# Altium schematic sheet GPS.SchDoc(U_GPS)
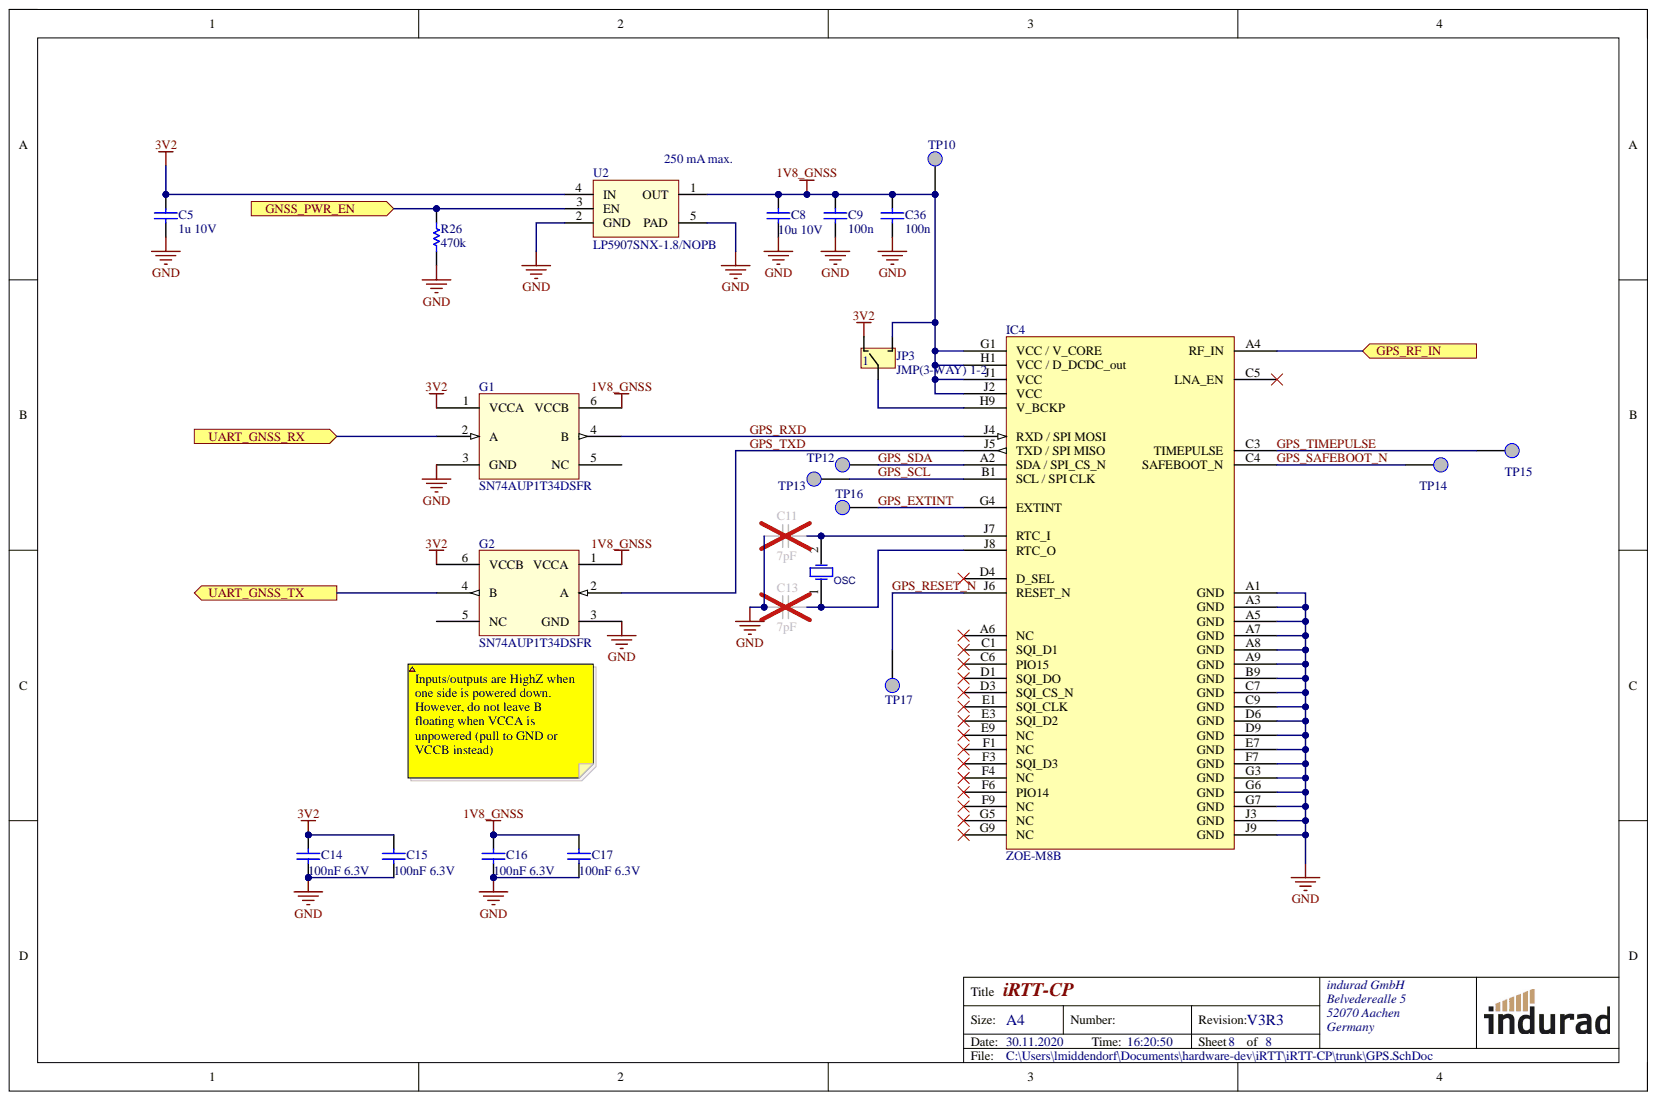
[diagram p0: GPS.SchDoc]

## Parts
C5  1u 10V  [0805_N_(2012)]
C8  10u 10V  value 10u  [0805_N_(2012)]
C9  100n  [0402_N_(1005)]
C11  7pF  [0402_N_(1005)]
C13  7pF  [0402_N_(1005)]
C14  100nF 6.3V  Voltage - Rated: 6.3V  [0201_N_(0603)]
C15  100nF 6.3V  Voltage - Rated: 6.3V  [0201_N_(0603)]
C16  100nF 6.3V  Voltage - Rated: 6.3V  [0201_N_(0603)]
C17  100nF 6.3V  Voltage - Rated: 6.3V  [0201_N_(0603)]
C36  100n  [0402_N_(1005)]
G1  SN74AUP1T34DSFR  [SON6]
G2  SN74AUP1T34DSFR  [SON6]
IC4  ZOE-M8B  [ZOE-M8]
JP3  JMP(3-WAY) 1-2  [JM0402(SET_1_2)(3WAY)]
OSC  CM8V-T1A-32.768kHz-7pF-20PPM  [Quarz_CM8V]  (value not drawn)
R26  470k  [0201_N_(0603)]
TP10  TP  [TP1.0d0.7]  (no BOM)
TP12  TP  [TP0.6d0.3]  (no BOM)
TP13  TP  [TP0.6d0.3]  (no BOM)
TP14  TP  [TP0.6d0.3]  (no BOM)
TP15  TP  [TP0.6d0.3]  (no BOM)
TP16  TP  [TP0.6d0.3]  (no BOM)
TP17  TP  [TP0.6d0.3]  (no BOM)
U2  LP5907SNX-1.8/NOPB  [4-XDFN]

## Ports
GNSS_PWR_EN, GPS_RF_IN, UART_GNSS_RX, UART_GNSS_TX

## Nets
1V8_GNSS: C8.2 C9.2 C16.1 C17.1 C36.2 G1.6(VCCB) G2.1(VCCA) IC4.G1(VCC_/_V_CORE) IC4.H1(VCC_/_D_DCDC_out) IC4.J1(VCC) IC4.J2(VCC) JP3.3 TP10.1 U2.1(OUT)
3V2: C5.2 C14.1 C15.1 G1.1(VCCA) G2.6(VCCB) JP3.1 U2.4(IN)
GND: C5.1 C8.1 C9.1 C11.1 C13.1 C14.2 C15.2 C16.2 C17.2 C36.1 G1.3(GND) G2.3(GND) IC4.A1(GND) IC4.A3(GND) IC4.A5(GND) IC4.A7(GND) IC4.A8(GND) IC4.A9(GND) IC4.B9(GND) IC4.C7(GND) IC4.C9(GND) IC4.D6(GND) IC4.D9(GND) IC4.E7(GND) IC4.F7(GND) IC4.G3(GND) IC4.G6(GND) IC4.G7(GND) IC4.J3(GND) IC4.J9(GND) R26.2 U2.2(GND) U2.5(PAD)
GNSS_PWR_EN: R26.1 U2.3(EN) ; port GNSS_PWR_EN
GPS_EXTINT: IC4.G4(EXTINT) TP16.1
GPS_RESET_N: IC4.J6(RESET_N) TP17.1
GPS_RF_IN: IC4.A4(RF_IN) ; port GPS_RF_IN
GPS_RXD: G1.4(B) IC4.J4(RXD_/_SPI_MOSI)
GPS_SAFEBOOT_N: IC4.C4(SAFEBOOT_N) TP14.1
GPS_SCL: IC4.B1(SCL_/_SPI_CLK) TP13.1
GPS_SDA: IC4.A2(SDA_/_SPI_CS_N) TP12.1
GPS_TIMEPULSE: IC4.C3(TIMEPULSE) TP15.1
GPS_TXD: G2.2(A) IC4.J5(TXD_/_SPI_MISO)
UART_GNSS_RX: G1.2(A) ; port UART_GNSS_RX
UART_GNSS_TX: G2.4(B) ; port UART_GNSS_TX
NetC11_2: C11.2 IC4.J7(RTC_I) OSC.2
NetC13_2: C13.2 IC4.J8(RTC_O) OSC.1
NetG1_5: G1.5(NC)
NetG2_5: G2.5(NC)
NetIC4_A6: IC4.A6(NC)
NetIC4_C1: IC4.C1(SQI_D1)
NetIC4_C5: IC4.C5(LNA_EN)
NetIC4_C6: IC4.C6(PIO15)
NetIC4_D1: IC4.D1(SQI_DO)
NetIC4_D3: IC4.D3(SQI_CS_N)
NetIC4_D4: IC4.D4(D_SEL)
NetIC4_E1: IC4.E1(SQI_CLK)
NetIC4_E3: IC4.E3(SQI_D2)
NetIC4_E9: IC4.E9(NC)
NetIC4_F1: IC4.F1(NC)
NetIC4_F3: IC4.F3(SQI_D3)
NetIC4_F4: IC4.F4(NC)
NetIC4_F6: IC4.F6(PIO14)
NetIC4_F9: IC4.F9(NC)
NetIC4_G5: IC4.G5(NC)
NetIC4_G9: IC4.G9(NC)
NetIC4_H9: IC4.H9(V_BCKP) JP3.2

## Library data of the parts
C5 = Cap: Capacitor; Manufacturer: KEMET; Manufacturer Part Number: C0805C105K8RACTU; Component Kind: Standard; ComponentLink1Description: http://www.farnell.com/datasheets/2207129.pdf; ComponentLink1URL: http://www.farnell.com/datasheets/2207129.pdf; ComponentLink2Description: http://www.farnell.com/datasheets/2205776.pdf; ComponentLink2URL: http://www.farnell.com/datasheets/2205776.pdf; ComponentLink3Description: http://www.farnell.com/cad/2268620.zip; ComponentLink3URL: http://www.farnell.com/cad/2268620.zip; Pricing 1: 10=0.0757, 100=0.0474, 500=0.028, 1000=0.0259, 2000=0.0238 (GBP); RoHS: YES; Signal Integrity: Cap; Simulation: CAP; Stock 1: 2580; Supplier 1: Farnell; Supplier Part Number 1: 2409048; Value: 1u 10V; Library Name: Indurad.SchLib
C8 = Cap: Capacitor; Component Kind: Standard; Signal Integrity: Cap; Simulation: CAP; Supplier 1: Farnell; Supplier Part Number 1: 2118132; Library Name: Indurad.SchLib
C9 C36 = Cap: Capacitor; Manufacturer: Murata Electronics North America; Manufacturer Part Number: GRM155R70J104KA01D; Capacitance: 0.1µF; Temperature Coefficient: X7R; Tolerance: ±10%; Voltage - Rated: 6.3V; Applications: General Purpose; Category: Capacitors; Component Kind: Standard; ComponentLink1Description: http://www.murata.com/~/media/webrenewal/support/library/catalog/products/capacitor/mlcc/c02e.ashx; ComponentLink1URL: http://www.murata.com/~/media/webrenewal/support/library/catalog/products/capacitor/mlcc/c02e.ashx; Features: -; Height - Seated (Max): -; Lead Spacing: -; Mounting Type: Surface Mount, MLCC; Operating Temperature: -55°C ~ 125°C; Package / Case: 0402 (1005 Metric); Packaging: Cut Tape (CT); Pricing 1: 1=0.1, 10=0.025, 50=0.0136, 100=0.0114, 250=0.00936, 500=0.008, 1000=0.00622, 5000=0.00519 (EUR); Ratings: -; RoHS: RoHS Compliant; Signal Integrity: Cap; Simulation: CAP; Size / Dimension: 0.039" L x 0.020" W (1.00mm x 0.50mm); Stock 1: 430852; Supplier 1: Digi-Key; Supplier Part Number 1: 490-6319-1-ND; Thickness (Max): 0.022" (0.55mm); Value: 100n; Library Name: Indurad.SchLib
C11 C13 = Cap: Capacitor; Manufacturer: Murata Electronics North America; Manufacturer Part Number: GRM1555C1H7R0DA01D; Notification: Current market demand for these product types have resulted in fluctuating and extending lead times. Lead times may differ.; Temperature Coefficient: C0G, NP0; Tolerance: ±0.5pF; Voltage - Rated: 50V; Applications: General Purpose; Category: Capacitors; Component Kind: Standard; ComponentLink1Description: https://www.murata.com/~/media/webrenewal/support/library/catalog/products/capacitor/mlcc/c02e.ashx?la=en-us; ComponentLink1URL: https://www.murata.com/~/media/webrenewal/support/library/catalog/products/capacitor/mlcc/c02e.ashx?la=en-us; Features: -; Height - Seated (Max): -; Lead Spacing: -; Lead Style: -; Mounting Type: Surface Mount, MLCC; Operating Temperature: -55°C ~ 125°C; Package / Case: 0402 (1005 Metric); Packaging: Cut Tape (CT); Part Status: Active; Pricing 1: 1=0.08, 10=0.027, 100=0.012, 500=0.00854, 1000=0.00671, 2500=0.0061, 5000=0.00561 (EUR); Ratings: -; RoHS: RoHS Compliant; Signal Integrity: Cap; Simulation: CAP; Size / Dimension: 0.039" L x 0.020" W (1.00mm x 0.50mm); Stock 1: 119890; Supplier 1: Digi-Key; Supplier Part Number 1: 490-5878-1-ND; Thickness (Max): 0.022" (0.55mm); Value: 7pF; Library Name: Indurad.SchLib
C14-C17 = Cap: Capacitor; Manufacturer: TDK Corporation; Manufacturer Part Number: C0603X5R0J104K030BC; Capacitance: 0.10µF; Temperature Coefficient: X5R; Tolerance: ±10%; Applications: General Purpose; Category: Capacitors; Component Kind: Standard; ComponentLink1Description: https://product.tdk.com/info/en/catalog/datasheets/mlcc_commercial_general_en.pdf; ComponentLink1URL: https://product.tdk.com/info/en/catalog/datasheets/mlcc_commercial_general_en.pdf; Features: -; Height - Seated (Max): -; Lead Spacing: -; Lead Style: -; Mounting Type: Surface Mount, MLCC; Operating Temperature: -55°C ~ 85°C; Package / Case: 0201 (0603 Metric); Packaging: Cut Tape (CT); Pricing 1: 1=0.09, 10=0.028, 100=0.0131, 250=0.01072, 500=0.00916, 1000=0.00713, 5000=0.00594 (EUR); Ratings: -; RoHS: RoHS Compliant; Signal Integrity: Cap; Simulation: CAP; Size / Dimension: 0.024" L x 0.012" W (0.60mm x 0.30mm); Stock 1: 1826831; Supplier 1: Digi-Key; Supplier Part Number 1: 445-1796-1-ND; Thickness (Max): 0.013" (0.33mm); Value: 100nF 6.3V; Library Name: Indurad.SchLib
G1 G2 = SN74AUP1T34DSFR: 1-Bit Unidirectional Voltage-Level Translator; Manufacturer: Texas Instruments; Channels per Circuit: 1; Output Type: Non-Inverted; Translator Type: Voltage Level; Base Part Number: 74AUP1T34; Category: Integrated Circuits (ICs); Channel Type: Unidirectional; Component Kind: Standard; ComponentLink1Description: http://www.ti.com/lit/ds/symlink/sn74aup1t34.pdf; ComponentLink1URL: http://www.ti.com/lit/ds/symlink/sn74aup1t34.pdf; Data Rate: -; Features: -; Input Signal: -; Manufacturer Part Number: SN74AUP1T34DSFR; Mounting Type: Surface Mount; Operating Temperature: -40°C ~ 85°C (TA); Output Signal: -; Package / Case: 6-XFDFN; Packaging: Cut Tape (CT); Part Status: Active; Pricing 1: 1=0.55, 10=0.486, 100=0.373, 500=0.29484, 1000=0.23587 (EUR); RoHS: RoHS Compliant; Stock 1: 10074; Supplier 1: Digi-Key; Supplier Device Package: 6-SON (1x1); Supplier Part Number 1: 296-36719-1-ND
  G2: Library Name: IC_Integrated_Circuit.SchLib; Supplier 2: Arrow; Supplier 3: Mouser; Supplier Part Number 2: SN74AUP1T34DSFR; Supplier Part Number 3: 595-SN74AUP1T34DSFR
  G1: Library Name: Indurad.SchLib
IC4 = ZOE-M8B: Manufacturer: u-blox; Component Kind: Standard; ComponentLink1Description: Datasheet; ComponentLink1URL: https://www.u-blox.com/sites/default/files/ZOE-M8B_DataSheet_%28UBX-17035164%29.pdf; Description: ZOE-M8B; Library Name: M_Module.SchLib; Manufacturer Part Number: ZOE-M8B; Supplier 1: Digi-Key; Supplier Part Number 1: 672-1063-1-ND
JP3 = JMP(3-WAY) 1-2: 0R 0402; Value: 0; Component Kind: Standard; Library Name: JP_Jumper.SchLib
OSC = CM8V-T1A-32.768kHz-7pF-20PPM: Quarz32.768KHz 20ppm 7.0pF -40C +85C; Manufacturer: Micro Crystal; Manufacturer Part Number: CM8V-T1A32.768kHz7pF+/-20ppmTAQC; Load Capacitance: 7pF; Case/Package: SMD/SMT; Component Kind: Standard; ComponentLink1Description: https://datasheet.ciiva.com/29123/001009262-da-01-en-uhrenquarz-cm8v-t1a-32-768-247-smd-29123814.pdf; ComponentLink1URL: https://datasheet.ciiva.com/29123/001009262-da-01-en-uhrenquarz-cm8v-t1a-32-768-247-smd-29123814.pdf; Frequency: 32.768KHz; Frequency Tolerance: 20ppm; Height: 0.6mm; Length: 2mm; Max Operating Temperature: 85°C; Min Operating Temperature: -40°C; Pricing 1: 1=2.19, 25=2.04, 100=1.95, 250=1.85, 500=1.76, 1000=1.66 (USD); Stock 1: 266; Supplier 1: Mouser; Supplier Part Number 1: 428-201436-MC01; Width: 1.2mm; Library Name: Indurad.SchLib
R26 = Res: Resistor; Component Kind: Standard; Signal Integrity: Res; Simulation: RESISTOR; Value: 470k; Library Name: Indurad.SchLib
TP10 TP12-TP17 = TP: Generic test point; Library Name: InduradCommon.SchLib
U2 = LP5907SNX-1.8: Ultralow-Noise, High PSRR, Fast RF 200mA LDO Linear Regulators (Rev. K); Manufacturer: Texas Instruments; Control Features: Enable; Current - Output: 250mA; Output Configuration: Positive; Output Type: Fixed; Protection Features: Over Temperature, Short Circuit; PSRR: 90dB ~ 60dB (100Hz ~ 100kHz); Voltage - Input (Max): 5.5V; Voltage - Output (Min/Fixed): 1.8V; Voltage Dropout (Max): 0.25V @ 250mA; Category: Integrated Circuits (ICs); Component Kind: Standard; ComponentLink1Description: http://www.ti.com/lit/ds/symlink/lp5907.pdf; ComponentLink1URL: http://www.ti.com/lit/ds/symlink/lp5907.pdf; Manufacturer Part Number: LP5907SNX-1.8/NOPB; Mounting Type: Surface Mount; Operating Temperature: -40°C ~ 125°C; Package / Case: 4-XDFN Exposed Pad; Packaging: Cut Tape (CT); Part Status: Active; Pricing 1: 1=0.48, 10=0.408, 100=0.3042, 500=0.23898, 1000=0.18467 (EUR); RoHS: RoHS Compliant; Stock 1: 6386; Supplier 1: Digi-Key; Supplier Device Package: 4-X2SON (1x1); Supplier Part Number 1: 296-40369-1-ND; Voltage - Output (Max): -; Library Name: Indurad.SchLib
